# Altium schematic sheet [3]-MCU_MAIN.SchDoc([3]-MCU_MAIN)
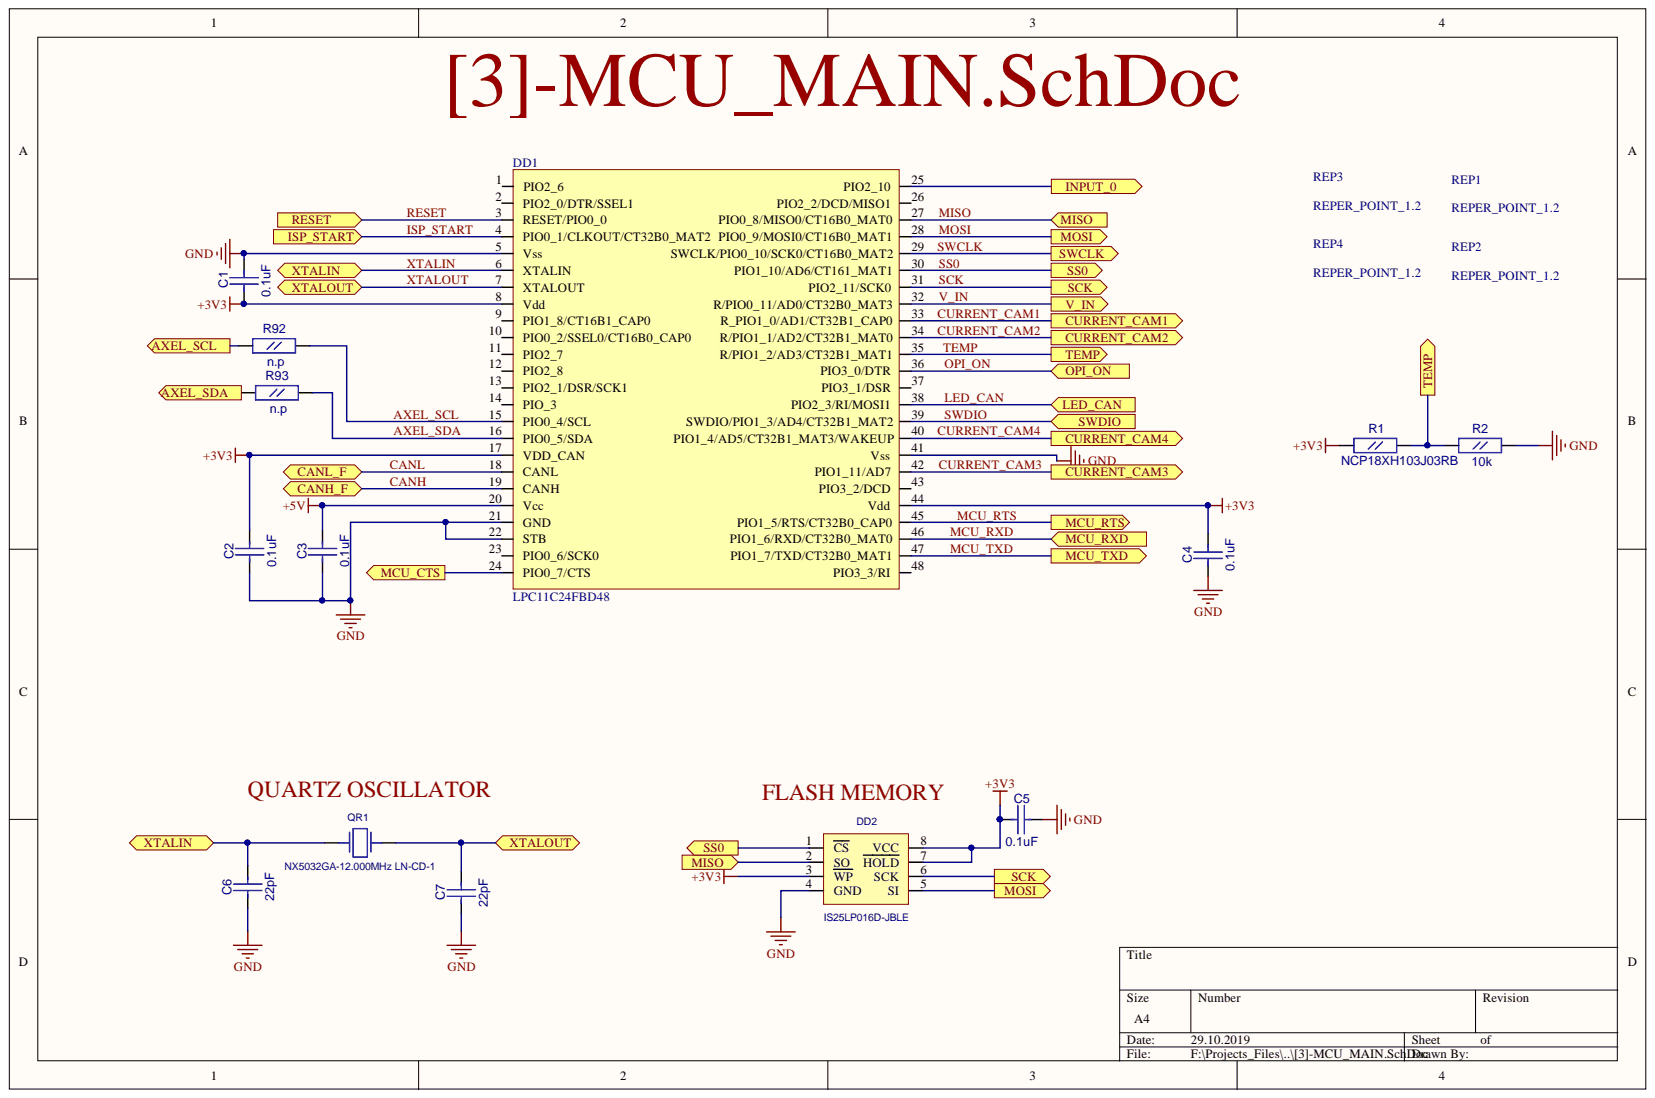
[diagram p0: [3]-MCU_MAIN.SchDoc]

## Parts
C1  0.1uF  [CC0603]
C2  0.1uF  [CC0603]
C3  0.1uF  [CC0603]
C4  0.1uF  [CC0603]
C5  0.1uF  [CC0603]
C6  22pF  [CC0603]
C7  22pF  [CC0603]
DD1  LPC11C24FBD48  [LQFP48]
DD2  IS25LP016D-JBLE  [EIAJ_SO8]
QR1  NX5032GA-12.000MHz LN-CD-1  [AC5032_2]
R1  NCP18XH103J03RB  [RC0603]
R2  10k  [RC0603]
R92  n.p  [RC0603]
R93  n.p  [RC0603]
REP1  REPER_POINT_1.2  [REPER1.2]
REP2  REPER_POINT_1.2  [REPER1.2]
REP3  REPER_POINT_1.2  [REPER1.2]
REP4  REPER_POINT_1.2  [REPER1.2]

## Ports
AXEL_SCL, AXEL_SDA, CANH_F, CANL_F, CURRENT_CAM1, CURRENT_CAM2, CURRENT_CAM3, CURRENT_CAM4, INPUT_0, ISP_START, LED_CAN, MCU_CTS, MCU_RTS, MCU_RXD, MCU_TXD, MISO, MOSI, OPI_ON, RESET, SCK, SS0, SWCLK, SWDIO, TEMP, V_IN, XTALIN, XTALOUT

## Nets
+3V3: C1.1 C2.2 C4.2 C5.1 DD1.8(Vdd) DD1.17(VDD_CAN) DD1.44(Vdd) DD2.3(WP) DD2.7(HOLD) DD2.8(VCC) R1.1
+5V: C3.2 DD1.20(Vcc)
GND: C1.2 C2.1 C3.1 C4.1 C5.2 C6.1 C7.1 DD1.5(Vss) DD1.21(GND) DD1.22(STB) DD1.41(Vss) DD2.4(GND) R2.2
AXEL_SCL: DD1.15 R92.2
AXEL_SDA: DD1.16 R93.2
CANH: DD1.19(CANH) ; port CANH_F
CANL: DD1.18(CANL) ; port CANL_F
CURRENT_CAM1: DD1.33(R_PIO1_0/AD1/CT32B1_CAP0) ; port CURRENT_CAM1
CURRENT_CAM2: DD1.34(R/PIO1_1/AD2/CT32B1_MAT0) ; port CURRENT_CAM2
CURRENT_CAM3: DD1.42(PIO1_11/AD7) ; port CURRENT_CAM3
CURRENT_CAM4: DD1.40(PIO1_4/AD5/CT32B1_MAT3/WAKEUP) ; port CURRENT_CAM4
INPUT_0: DD1.25(PIO2_10) ; port INPUT_0
ISP_START: DD1.4 ; port ISP_START
LED_CAN: DD1.38(PIO2_3/RI/MOSI1) ; port LED_CAN
MCU_CTS: DD1.24 ; port MCU_CTS
MCU_RTS: DD1.45(PIO1_5/RTS/CT32B0_CAP0) ; port MCU_RTS
MCU_RXD: DD1.46(PIO1_6/RXD/CT32B0_MAT0) ; port MCU_RXD
MCU_TXD: DD1.47(PIO1_7/TXD/CT32B0_MAT1) ; port MCU_TXD
MISO: DD1.27 DD2.2(SO) ; port MISO
MOSI: DD1.28 DD2.5(SI) ; port MOSI
OPI_ON: DD1.36(PIO3_0/DTR) ; port OPI_ON
RESET: DD1.3(RESET/PIO0_0) ; port RESET
SCK: DD1.31(PIO2_11/SCK0) DD2.6(SCK) ; port SCK
SS0: DD1.30(PIO1_10/AD6/CT161_MAT1) DD2.1(CS) ; port SS0
SWCLK: DD1.29(SWCLK/PIO0_10/SCK0/CT16B0_MAT2) ; port SWCLK
SWDIO: DD1.39(SWDIO/PIO1_3/AD4/CT32B1_MAT2) ; port SWDIO
TEMP: DD1.35(R/PIO1_2/AD3/CT32B1_MAT1) R1.2 R2.1 ; port TEMP
V_IN: DD1.32(R/PIO0_11/AD0/CT32B0_MAT3) ; port V_IN
XTALIN: C6.2 DD1.6(XTALIN) QR1.1 ; port XTALIN
XTALOUT: C7.2 DD1.7(XTALOUT) QR1.2 ; port XTALOUT
NetR92_1: R92.1 ; port AXEL_SCL
NetR93_1: R93.1 ; port AXEL_SDA
CURRENT_CAM2:
CURRENT_CAM4:
LED_CAN:
MCU_RTS:
OPI_ON:
TEMP:

Not connected: DD1 1(PIO2_6) 2(PIO2_0/DTR/SSEL1) 9(PIO1_8/CT16B1_CAP0) 10 11(PIO2_7) 12(PIO2_8) 13(PIO2_1/DSR/SCK1) 14(PIO_3) 23 26(PIO2_2/DCD/MISO1) 37(PIO3_1/DSR) 43(PIO3_2/DCD) 48(PIO3_3/RI)

## Library data of the parts
C1-C7 = CC0603: Description: CC0603; Component Kind: Standard
  C1-C5: Value: 0.1uF
  C6 C7: Value: 22pF
  C1-C4: Library Name: fizik_lib.SchLib
  C5-C7: Library Name: gprs_modem_2.SchLib
DD2 = S25FL016A0LMFI01: Value: IS25LP016D-JBLE; Description: S25FL016A0LMFI01; Component Kind: Standard; Library Name: gprs_modem_2.SchLib
QR1 = AC5032_2: AC5032/2; Value: NX5032GA-12.000MHz LN-CD-1; Component Kind: Standard; Library Name: gprs_modem_2.SchLib
R1 R2 R92 R93 = RC0603: Description: RC0603; Component Kind: Standard; Library Name: fizik_lib.SchLib
  R1: Value: NCP18XH103J03RB
  R2: Value: 10k
  R92 R93: Value: n.p
REP1-REP4 = REPER1.2: Value: REPER_POINT_1.2; Description: REPER1.2; Component Kind: Standard; Library Name: fizik_lib.SchLib
DD1 = LPC11C24FBD48: Value: LPC11C24FBD48; Component Kind: Standard; Library Name: fizik_lib.SchLib
